# Altium schematic sheet ANALOG.SchDoc(ANALOG)
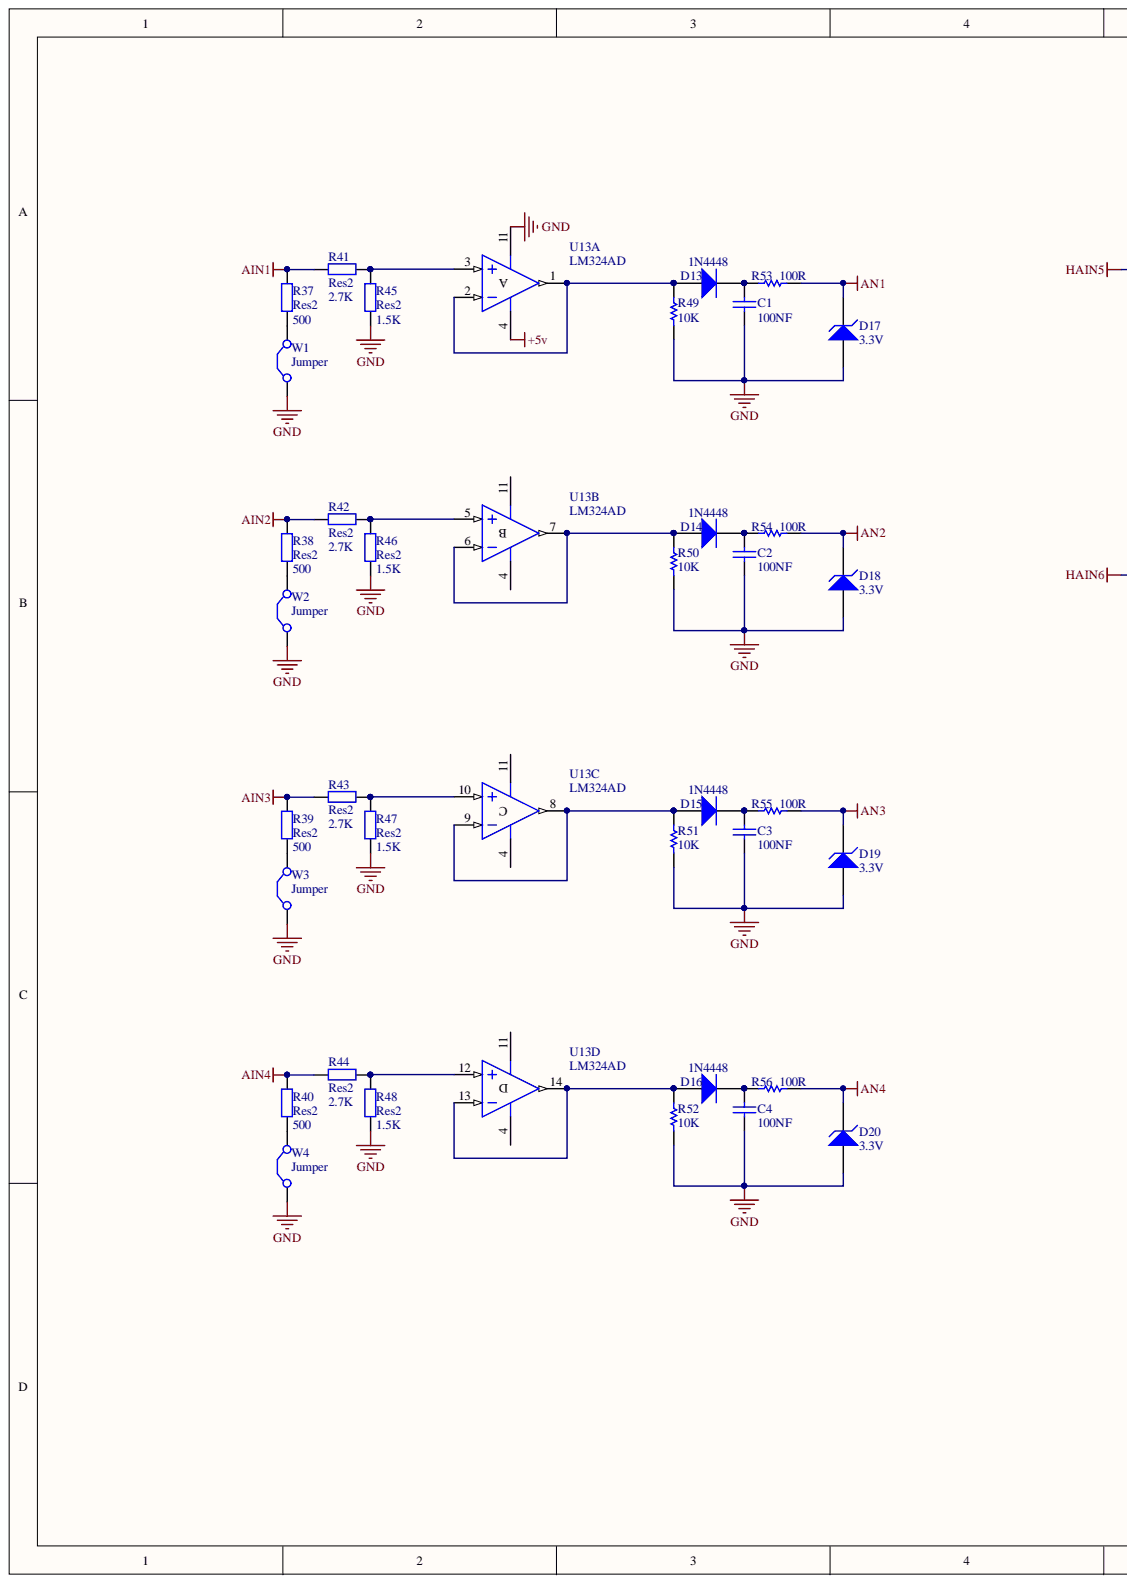
[diagram p0: ANALOG.SchDoc, left]
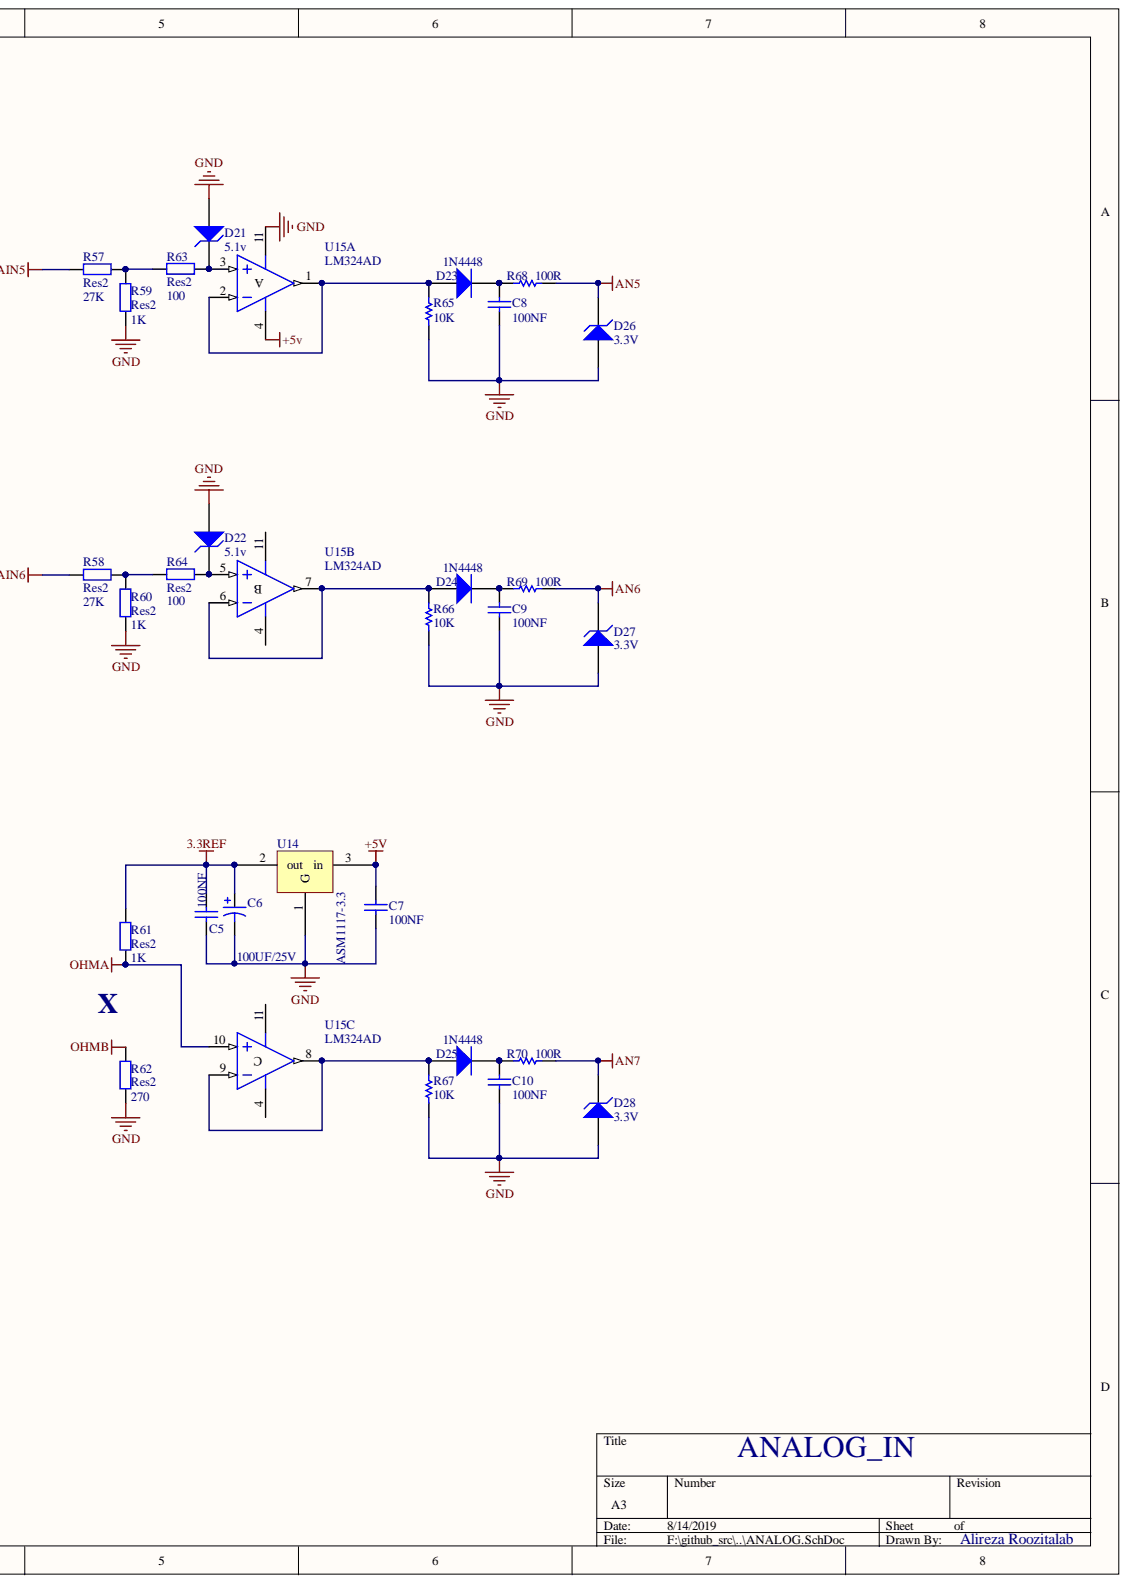
[diagram p1: ANALOG.SchDoc, right]

## Parts
C1  value 100NF  [CAP 1206 (3216X08L)]  (hidden comment: Cap)
C2  value 100NF  [CAP 1206 (3216X08L)]  (hidden comment: Cap)
C3  value 100NF  [CAP 1206 (3216X08L)]  (hidden comment: Cap)
C4  value 100NF  [CAP 1206 (3216X08L)]  (hidden comment: Cap)
C5  value 100NF  [CAP 1206 (3216X08L)]  (hidden comment: Cap)
C6  value 100UF/25V  [POL 100U/25V]  (hidden comment: Cap Pol1)
C7  value 100NF  [CAP 1206 (3216X08L)]  (hidden comment: Cap)
C8  value 100NF  [CAP 1206 (3216X08L)]  (hidden comment: Cap)
C9  value 100NF  [CAP 1206 (3216X08L)]  (hidden comment: Cap)
C10  value 100NF  [CAP 1206 (3216X08L)]  (hidden comment: Cap)
D13  1N4448  [Diode-1n4148 - SMD PAD]
D14  1N4448  [Diode-1n4148 - SMD PAD]
D15  1N4448  [Diode-1n4148 - SMD PAD]
D16  1N4448  [Diode-1n4148 - SMD PAD]
D17  3.3V  [Diode-1n4148 - SMD PAD]
D18  3.3V  [Diode-1n4148 - SMD PAD]
D19  3.3V  [Diode-1n4148 - SMD PAD]
D20  3.3V  [Diode-1n4148 - SMD PAD]
D21  5.1v  [Diode-1n4148 - SMD PAD]
D22  5.1v  [Diode-1n4148 - SMD PAD]
D23  1N4448  [Diode-1n4148 - SMD PAD]
D24  1N4448  [Diode-1n4148 - SMD PAD]
D25  1N4448  [Diode-1n4148 - SMD PAD]
D26  3.3V  [Diode-1n4148 - SMD PAD]
D27  3.3V  [Diode-1n4148 - SMD PAD]
D28  3.3V  [Diode-1n4148 - SMD PAD]
R37  Res2  value 500  [RES 1206 (3216X08L)]
R38  Res2  value 500  [RES 1206 (3216X08L)]
R39  Res2  value 500  [RES 1206 (3216X08L)]
R40  Res2  value 500  [RES 1206 (3216X08L)]
R41  Res2  value 2.7K  [RES 1206 (3216X08L)]
R42  Res2  value 2.7K  [RES 1206 (3216X08L)]
R43  Res2  value 2.7K  [RES 1206 (3216X08L)]
R44  Res2  value 2.7K  [RES 1206 (3216X08L)]
R45  Res2  value 1.5K  [RES 1206 (3216X08L)]
R46  Res2  value 1.5K  [RES 1206 (3216X08L)]
R47  Res2  value 1.5K  [RES 1206 (3216X08L)]
R48  Res2  value 1.5K  [RES 1206 (3216X08L)]
R49  value 10K  [RES 1206 (3216X08L)]  (hidden comment: Res Semi)
R50  value 10K  [RES 1206 (3216X08L)]  (hidden comment: Res Semi)
R51  value 10K  [RES 1206 (3216X08L)]  (hidden comment: Res Semi)
R52  value 10K  [RES 1206 (3216X08L)]  (hidden comment: Res Semi)
R53  value 100R  [RES 1206 (3216X08L)]  (hidden comment: Res Semi)
R54  value 100R  [RES 1206 (3216X08L)]  (hidden comment: Res Semi)
R55  value 100R  [RES 1206 (3216X08L)]  (hidden comment: Res Semi)
R56  value 100R  [RES 1206 (3216X08L)]  (hidden comment: Res Semi)
R57  Res2  value 27K  [RES 1206 (3216X08L)]
R58  Res2  value 27K  [RES 1206 (3216X08L)]
R59  Res2  value 1K  [RES 1206 (3216X08L)]
R60  Res2  value 1K  [RES 1206 (3216X08L)]
R61  Res2  value 1K  [RES 1206 (3216X08L)]
R62  Res2  value 270  [RES 1206 (3216X08L)]
R63  Res2  value 100  [RES 1206 (3216X08L)]
R64  Res2  value 100  [RES 1206 (3216X08L)]
R65  value 10K  [RES 1206 (3216X08L)]  (hidden comment: Res Semi)
R66  value 10K  [RES 1206 (3216X08L)]  (hidden comment: Res Semi)
R67  value 10K  [RES 1206 (3216X08L)]  (hidden comment: Res Semi)
R68  value 100R  [RES 1206 (3216X08L)]  (hidden comment: Res Semi)
R69  value 100R  [RES 1206 (3216X08L)]  (hidden comment: Res Semi)
R70  value 100R  [RES 1206 (3216X08L)]  (hidden comment: Res Semi)
U13  LM324AD  [SOP-14]  (parts A,B,C,D)
U14  ASM1117-3.3  [SOT-223]
U15  LM324AD  [SOP-14]  (parts A,B,C)
W1  Jumper  [RES 0402 (1005X04L)]
W2  Jumper  [RES 0402 (1005X04L)]
W3  Jumper  [RES 0402 (1005X04L)]
W4  Jumper  [RES 0402 (1005X04L)]

## Nets
3.3REF: C5.2 C6.1 R61.2 U14.2
+5v: C7.2 U13.4 U14.3 U15.4
AIN1: R37.2 R41.1
AIN2: R38.2 R42.1
AIN3: R39.2 R43.1
AIN4: R40.2 R44.1
AN1: D17.2 R53.2
AN2: D18.2 R54.2
AN3: D19.2 R55.2
AN4: D20.2 R56.2
AN5: D26.2 R68.2
AN6: D27.2 R69.2
AN7: D28.2 R70.2
GND: C1.2 C2.2 C3.2 C4.2 C5.1 C6.2 C7.1 C8.2 C9.2 C10.2 D17.1 D18.1 D19.1 D20.1 D21.1 D22.1 D26.1 D27.1 D28.1 R45.1 R46.1 R47.1 R48.1 R49.1 R50.1 R51.1 R52.1 R59.1 R60.1 R62.1 R65.1 R66.1 R67.1 U13.11 U14.1 U15.11 W1.1 W2.1 W3.1 W4.1
HAIN5: R57.1
HAIN6: R58.1
OHMA: R61.1 U15.10
OHMB: R62.2
NetC1_1: C1.1 D13.2 R53.1
NetC2_1: C2.1 D14.2 R54.1
NetC3_1: C3.1 D15.2 R55.1
NetC4_1: C4.1 D16.2 R56.1
NetC8_1: C8.1 D23.2 R68.1
NetC9_1: C9.1 D24.2 R69.1
NetC10_1: C10.1 D25.2 R70.1
NetD13_1: D13.1 R49.2 U13.1 U13.2
NetD14_1: D14.1 R50.2 U13.6 U13.7
NetD15_1: D15.1 R51.2 U13.8 U13.9
NetD16_1: D16.1 R52.2 U13.13 U13.14
NetD21_2: D21.2 R63.2 U15.3
NetD22_2: D22.2 R64.2 U15.5
NetD23_1: D23.1 R65.2 U15.1 U15.2
NetD24_1: D24.1 R66.2 U15.6 U15.7
NetD25_1: D25.1 R67.2 U15.8 U15.9
NetR37_1: R37.1 W1.2
NetR38_1: R38.1 W2.2
NetR39_1: R39.1 W3.2
NetR40_1: R40.1 W4.2
NetR41_2: R41.2 R45.2 U13.3
NetR42_2: R42.2 R46.2 U13.5
NetR43_2: R43.2 R47.2 U13.10
NetR44_2: R44.2 R48.2 U13.12
NetR57_2: R57.2 R59.2 R63.1
NetR58_2: R58.2 R60.2 R64.1

## Library data of the parts
C1-C5 C7-C10 = Cap: Capacitor; Simulation: CAP; Signal Integrity: Cap; Published: 8-Jun-2000; PackageReference: RAD-0.3; Library Name: Miscellaneous Devices.IntLib; LatestRevisionNote: Re-released for DXP Platform.; LatestRevisionDate: 17-Jul-2002; Component Kind: Standard; Publisher: Altium Limited
C6 = Cap Pol1: Polarized Capacitor (Radial); Simulation: CAP; Signal Integrity: Cap Pol; Published: 8-Jun-2000; PackageReference: RB7.6-15; Library Name: Miscellaneous Devices.IntLib; LatestRevisionNote: Re-released for DXP Platform.; LatestRevisionDate: 17-Jul-2002; Component Kind: Standard; Publisher: Altium Limited
D13-D16 D23-D25 = Diode: Default Diode; Simulation: DIODE; Signal Integrity: Diode; Published: 8-Jun-2000; PackageReference: SMC; PackageDocument: Sep-1996; Library Name: Miscellaneous Devices.IntLib; LatestRevisionNote: Re-released for DXP Platform.; LatestRevisionDate: 17-Jul-2002; Component Kind: Standard; Code_JEDEC: DO-214-AB; Publisher: Altium Limited
D17-D22 D26-D28 = D Zener: Zener Diode; Simulation: ZENER; Signal Integrity: D Zener; Published: 8-Jun-2000; PackageReference: DIODE-0.7; Library Name: Miscellaneous Devices.IntLib; LatestRevisionNote: Re-released for DXP Platform.; LatestRevisionDate: 17-Jul-2002; Component Kind: Standard; Publisher: Altium Limited
R37-R40 R43-R48 R57-R64 = Res2: Resistor; Simulation: RESISTOR; Signal Integrity: Res; Published: 8-Jun-2000; PackageReference: AXIAL-0.4; Library Name: Miscellaneous Devices.IntLib; LatestRevisionNote: Re-released for DXP Platform.; LatestRevisionDate: 17-Jul-2002; Component Kind: Standard; Publisher: Altium Limited
R41 R42 = Res2: Resistor; Value: 2.7K; Simulation: RESISTOR; Signal Integrity: Res; Published: 8-Jun-2000; PackageReference: AXIAL-0.4; Library Name: Miscellaneous Devices.IntLib; LatestRevisionNote: Re-released for DXP Platform.; LatestRevisionDate: 17-Jul-2002; Component Kind: Standard; Publisher: Altium Limited
R49-R56 R65-R70 = Res Semi: Semiconductor Resistor; Simulation: RES; Signal Integrity: Res Semi; Published: 8-Jun-2000; PackageReference: AXIAL-0.5; Library Name: Miscellaneous Devices.IntLib; LatestRevisionNote: Re-released for DXP Platform.; LatestRevisionDate: 17-Jul-2002; Component Kind: Standard; Publisher: Altium Limited
U13 U15 = LM324AD: Low-Power Quad Operational Amplifier; Simulation: LM324; Published: 24-Aug-2001; PCB3D: SO14; PackageVersion: Sep-1998; PackageReference: SO14; PackageDescription: 14-Pin Small Outline Integrated Circuit 1.27 mm Pitch; Library Name: ST Operational Amplifier.IntLib; LatestRevisionNote: IPC-7351 Footprint Added.; LatestRevisionDate: 13-Apr-2006; DatasheetVersion: Nov-1997; ComponentLink2URL: http://www.st.com/stonline/products/literature/ds/4797/lm224a.pdf; ComponentLink2Description: Datasheet; ComponentLink1URL: http://www.st.com/; ComponentLink1Description: Manufacturer Link; Component Kind: Standard; Code_IPC: SOIC127P600-14; Publisher: Altium Limited
W1-W4 = Jumper: Jumper Wire; Published: 24-Mar-1999; PackageReference: RAD-0.2; Library Name: Miscellaneous Devices.IntLib; LatestRevisionNote: Re-released for DXP Platform.; LatestRevisionDate: 17-Jul-2002; Component Kind: Standard; Publisher: Altium Limited
U14 = ASM1117-3.3: Component Kind: Standard; Library Name: LPC.SchLib
